# Altium schematic sheet PedalBox.SchDoc
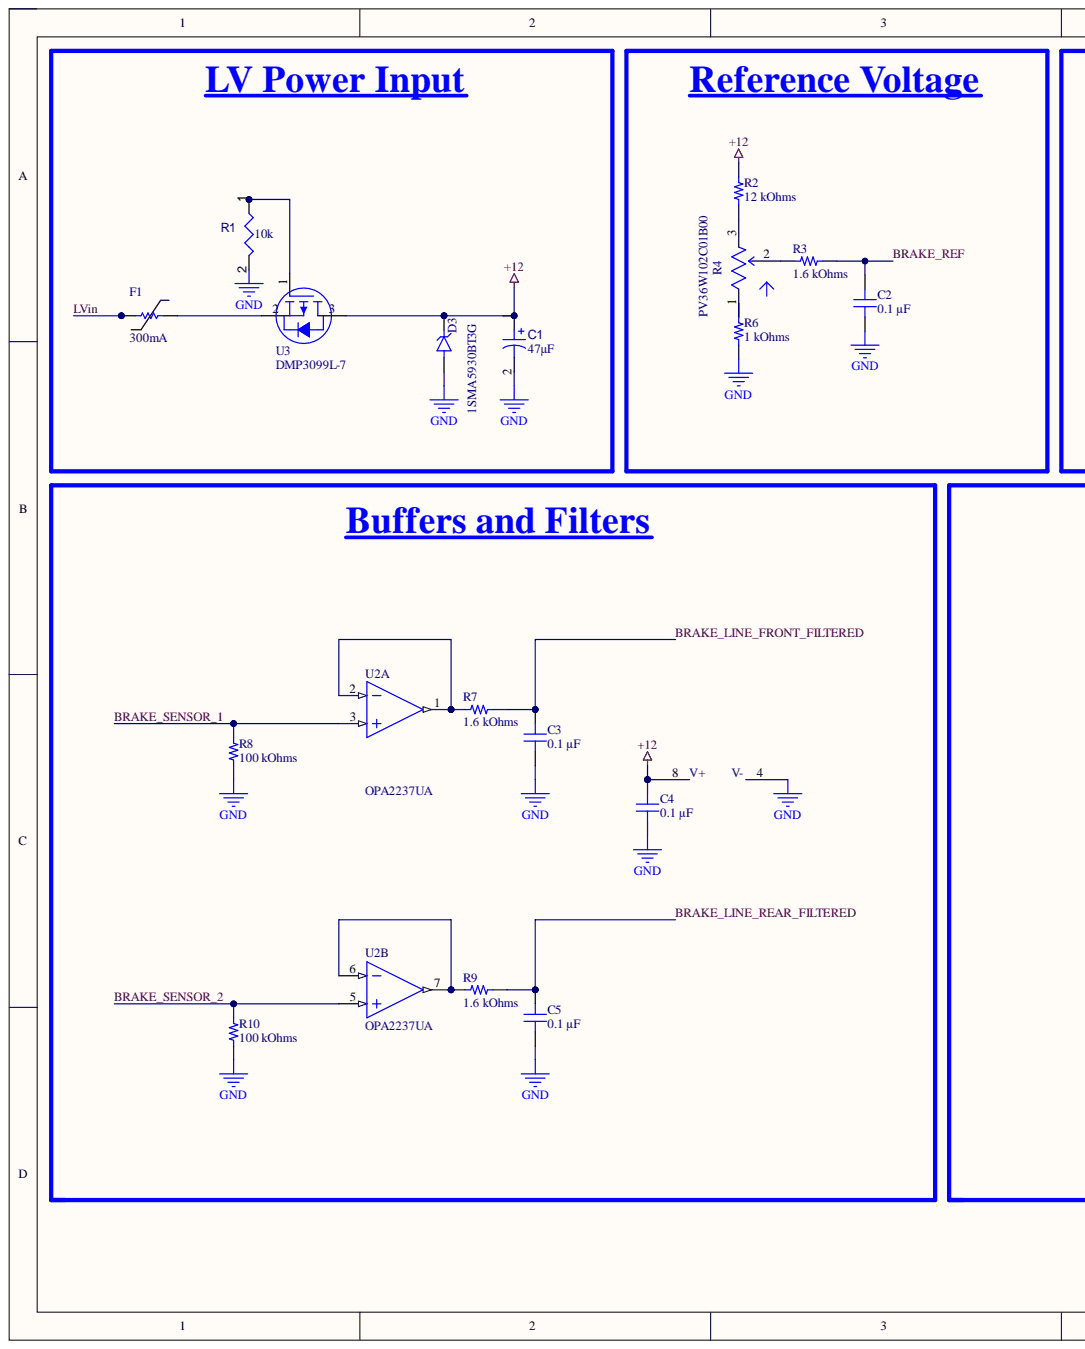
[diagram p0: PedalBox.SchDoc, left]
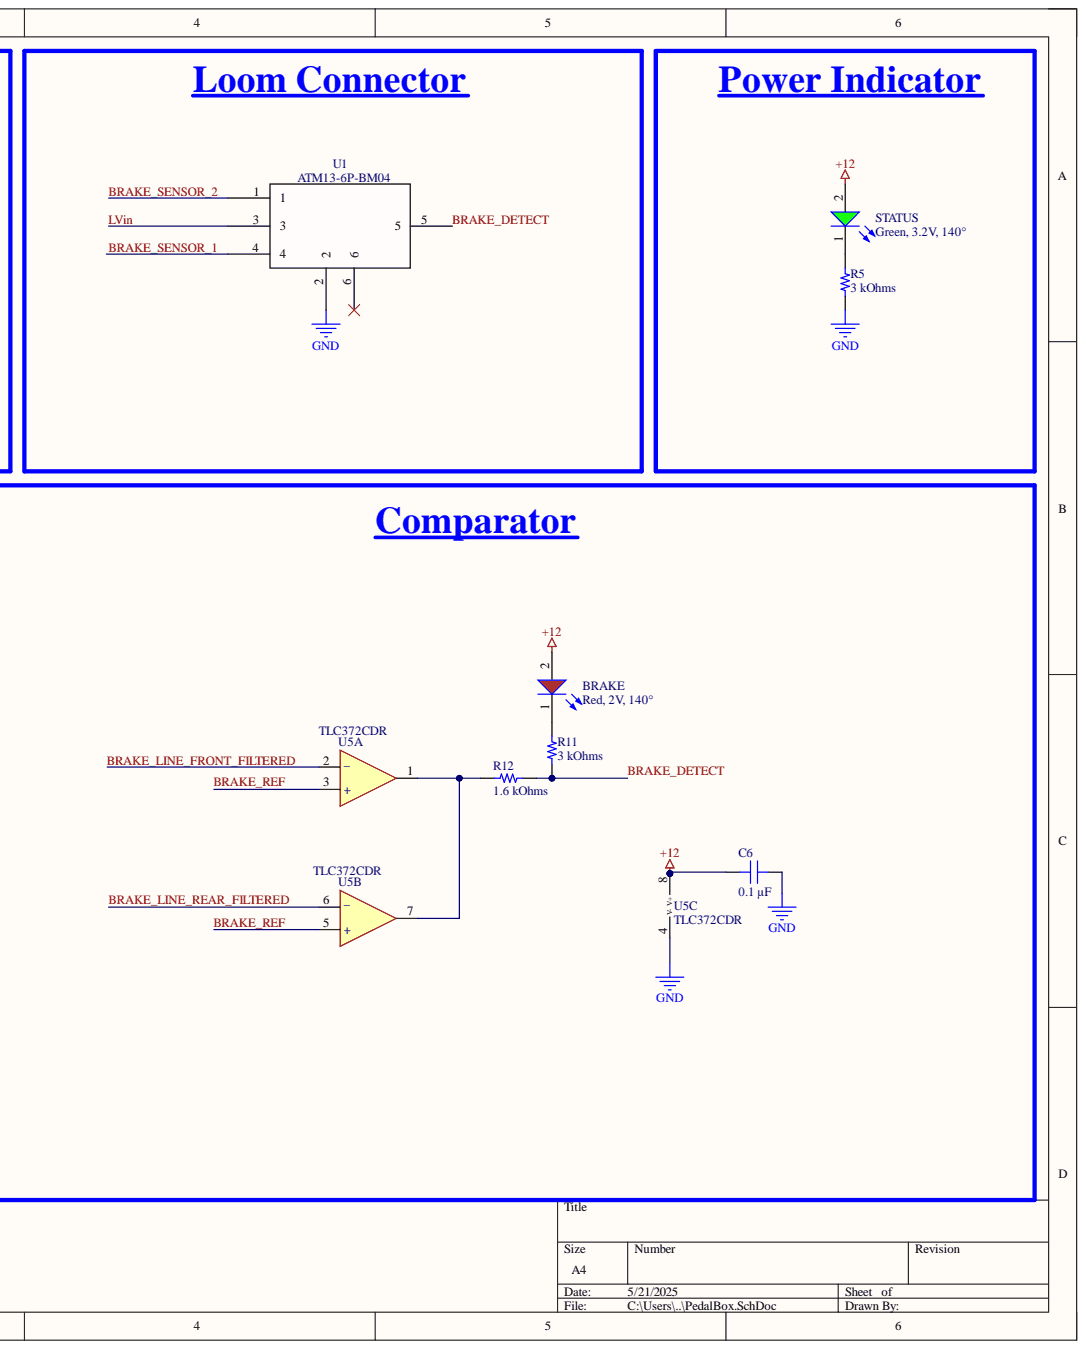
[diagram p1: PedalBox.SchDoc, right]

## Parts
BRAKE  Red, 2V, 140°  [WL-SMCW_0805_150080xx75000]
C1  value 47µF  [293D476X9025D2TE3-Footprint-1]  (hidden comment: 293D476X9025D2TE3)
C2  0.1 µF  [CAP 0603_1608]
C3  0.1 µF  [CAP 0603_1608]
C4  0.1 µF  [CAP 0603_1608]
C5  0.1 µF  [CAP 0603_1608]
C6  0.1 µF  [CAP 0603_1608]
D3  1SMA5930BT3G  [DIOM5226X220N]
F1  300mA  [RESC1608N]
R1  value 10k  [RESC1608X55X25ML10T15]  (hidden comment: Resistor 10k +/-1% 0603 100 mW)
R2  12 kOhms  [RES 0603_1608]
R3  1.6 kOhms  [RES 0603_1608]
R4  PV36W102C01B00  [FP-PV36W-MFG]
R5  3 kOhms  [RES 0603_1608]
R6  1 kOhms  [RES 0603_1608]
R7  1.6 kOhms  [RES 0603_1608]
R8  100 kOhms  [RES 0603_1608]
R9  1.6 kOhms  [RES 0603_1608]
R10  100 kOhms  [RES 0603_1608]
R11  3 kOhms  [RES 0603_1608]
R12  1.6 kOhms  [RES 0603_1608]
STATUS  Green, 3.2V, 140°  [WL-SMCW_0805_150080xx75000]
U1  ATM13-6P-BM04  [ATM13-6P-BM04_AMP]
U2  OPA2237UA  [D0008A_L]  (parts A,B)
U3  DMP3099L-7  [FP-SOT23-IPC_C]
U5  TLC372CDR  [TLC372CDR-Footprint-1]  (parts A,B,C)

## Nets
+12: BRAKE.2 C1.1 C4.2 C6.1 D3.C R2.2 STATUS.2 U2.8 U3.3 U5.8(V+)
GND: C1.2 C2.1 C3.1 C4.1 C5.1 C6.2 D3.A R1.2 R5.1 R6.1 R8.1 R10.1 U1.2 U2.4 U5.4(V-)
BRAKE_DETECT: R11.1 R12.2 U1.5
BRAKE_LINE_FRONT_FILTERED: C3.2 R7.2 U5.2
BRAKE_LINE_REAR_FILTERED: C5.2 R9.2 U5.6
BRAKE_REF: C2.2 R3.2 U5.3(+) U5.5(+)
BRAKE_SENSOR_1: R8.2 U1.4 U2.3
BRAKE_SENSOR_2: R10.2 U1.1 U2.5
LVin: F1.1 U1.3
NetBRAKE_1: BRAKE.1 R11.2
NetF1_2: F1.2 U3.2
NetR1_1: R1.1 U3.1
NetR2_1: R2.1 R4.3
NetR3_1: R3.1 R4.2
NetR4_1: R4.1 R6.2
NetR5_2: R5.2 STATUS.1
NetR7_1: R7.1 U2.1 U2.2
NetR9_1: R9.1 U2.6 U2.7
NetR12_1: R12.1 U5.1 U5.7

Not connected: U1 6

## Library data of the parts
BRAKE = 150080RS75000: WL-SMCW Mono-color Chip LED Waterclear, SMT, size 0805, Red, 2V, 140°; Manufacturer: Wurth Elektronik; Case/Size Code: 0805; Chip Technology: AlInGaP; Dominant Wavelength: 625nm; Luminous Intensity: 150mcd; Peak Wavelength: 630nm; Category: Signal LEDs; Component Kind: Standard; ComponentLink1Description: Website Link; ComponentLink1URL: https://www.we-online.com/redexpert/article/150080RS75000?ad; ComponentLink2Description: Datasheet Link; ComponentLink2URL: https://www.we-online.com/redexpert/spec/150080RS75000?ad; Emitting Color: Red; Forward Voltage: 2V; Height: 0.7mm; Length: 2mm; Library Name: Altium_WL-SMCW_rev22d.IntLib; Manufacturer Part Number: 150080RS75000; Match Code: WL-SMCW; Mount: Surface Mount; Operating Temperature Max: 85°C; Operating Temperature Min: -40°C; Packaging: Tape and Reel; Simulation: 0805_150080RS75000; Viewing Angle: 140°; Width: 1.25mm
C1 = CMP-001-00090-3: Tantalum Capacitor, Polarized, Tantalum (dry/solid), 25V, 10% +Tol, 10% -Tol, 47uF, Surface Mount, 2917; Ripple Current: 460mA; Capacitor Type: Tantalum; Case/Package: 2917; Component Kind: Standard; Max Operating Temperature: 125°C; Min Operating Temperature: -55°C; Mounting Technology: Surface Mount; Pins: 2; RoHS Compliant: Yes; Library Name: APAC - University of Canterbury - UC Motorsport (UCM)
C2-C6 = 100X14W104MV4T: CAP CER 0.1UF 10V X7R 0603; manufacturer: Johanson Dielectrics Inc.; Database Table Name: Capacitors - Ceramic - 0603; Tolerance: ±20%; Applications: Bypass, Decoupling; Capacitance: 0.1 µF; Component Kind: Standard; componentlink1description: Datasheet; componentlink1url: https://www.johansondielectrics.com/downloads/x2y-filter-and-decoupling-capacitors.pdf; componentlink2description: DigiKey Link; componentlink2url: https://www.digikey.com/en/products/detail/johanson-dielectrics-inc/100X14W104MV4T/1655914; Device Package: 0603 (1608 Metric); Features: Low ESL (X2Y); lastupdated: 9/02/2024 8:10:30 pm; Library Name: Celestial Altium Library - altium_library.DbLib; Lifecycle Status: Active; Minimum Order: 1; Mounting Type: Surface Mount, MLCC; Operating Temperature: -55°C ~ 125°C; Package / Case: 0603 (1608 Metric); packaging: TapeAndReel; Part Number: 100X14W104MV4T; Part Status: Active; partid: 2680; price: 0.08; series: X2Y®; Size / Dimension: 0.064" L x 0.035" W (1.63mm x 0.89mm); Supplier 1: DigiKey; Supplier Part Number 1: 709-1101-1-ND; Temperature Coefficient: X7R; Thickness (Max): 0.026" (0.66mm); value: 0.1 µF; Voltage - Rated: 10V
D3 = CMP-004-00032-1: Availability: Unavailable; Case/Package: None; Component Kind: Standard; Component Type: Standard; Design Item ID: 1SMA5930BT3G; MP: 1SMA5930BT3G; Pins: 2; Price: None; Source: 1SMA5930BT3G.SchLib; Library Name: APAC - University of Canterbury - UC Motorsport (UCM)
F1 = FS_Fuse_PTC_0.3A: FUSE PTC RESETTABLE 0603 SMD 0.1A; Manufacturer: Bourns Inc.; Manufacturer Part Number: MF-FSMF010X-2; Current - Hold (Ih) (Max): 100mA; Current - Max: 40A; R Min/Max: 0.900 ~ 6.000 Ohm; Component Kind: Standard; Current - Trip (It): 300mA; Library Name: ECE_ComponentsAD23_2.IntLib; Package / Case: 0603 (1608 Metric), Concave; Supplier 1: Digi-Key; Supplier Part Number 1: MF-FSMF010X-2CT-ND
R1 = CMP-009-00017-5: Chip Resistor, 10 KOhm, +/- 1%, 01 W, -55 to 155 degC, 0603 (1608 Metric); Power: 100mW; Voltage Rating: 50V; Case/Package: 0603; Component Kind: Standard; Max Operating Temperature: 155°C; Min Operating Temperature: -55°C; Mounting Technology: SM; Pins: 2; RoHS Compliant: True; Tolerance: 1%; Library Name: APAC - University of Canterbury - UC Motorsport (UCM)
R2 = RMCF0603JT12K0: RES 12K OHM 5% 1/10W 0603; Manufacturer: Stackpole Electronics Inc; Database Table Name: Resistors - Surface Mount - 0603; Power (Watts): 0.1W, 1/10W; Temperature Coefficient: ±200ppm/°C; Component Kind: Standard; ComponentLink1Description: Datasheet; ComponentLink1URL: https://www.seielect.com/catalog/sei-rmcf_rmcp.pdf; ComponentLink2Description: DigiKey Link; ComponentLink2URL: https://www.digikey.com/en/products/detail/stackpole-electronics-inc/RMCF0603JT12K0/1757966; Composition: Thick Film; Device Package: 0603 (1608 Metric); Features: Automotive AEC-Q200; Height - Seated (Max): 0.022" (0.55mm); LastUpdated: 9/02/2024 8:43:36 pm; Library Name: Celestial Altium Library - altium_library.DbLib; Lifecycle Status: Active; Minimum Order: 1; Number of Terminations: 2; Operating Temperature: -55°C ~ 155°C; Package / Case: 0603 (1608 Metric); Packaging: CutTape; Part Number: RMCF0603JT12K0; Part Status: Active; PartId: 5166; Price: 0.01; Ratings: AEC-Q200; Resistance: 12 kOhms; Series: RMCF; Size / Dimension: 0.061" L x 0.031" W (1.55mm x 0.80mm); Supplier 1: DigiKey; Supplier Device Package: 0603; Supplier Part Number 1: RMCF0603JT12K0CT-ND; Tolerance: ±5%; Value: 12 kOhms
R3 R7 R9 R12 = ERJ-PB3B1601V: RES SMD 1.6K OHM 0.1% 1/5W 0603; Manufacturer: Panasonic Electronic Components; Database Table Name: Resistors - Surface Mount - 0603; Power (Watts): 0.2W, 1/5W; Temperature Coefficient: ±50ppm/°C; Component Kind: Standard; ComponentLink1Description: Datasheet; ComponentLink1URL: https://industrial.panasonic.com/cdbs/www-data/pdf/RDM0000/AOA0000C328.pdf; ComponentLink2Description: DigiKey Link; ComponentLink2URL: https://www.digikey.com/en/products/detail/panasonic-electronic-components/ERJ-PB3B1601V/6212776; Composition: Thick Film; Device Package: 0603 (1608 Metric); Features: Automotive AEC-Q200, Pulse Withstanding; Height - Seated (Max): 0.022" (0.55mm); LastUpdated: 9/02/2024 8:43:37 pm; Library Name: Celestial Altium Library - altium_library.DbLib; Lifecycle Status: Active; Minimum Order: 1; Number of Terminations: 2; Operating Temperature: -55°C ~ 155°C; Package / Case: 0603 (1608 Metric); Packaging: TapeAndReel; Part Number: ERJ-PB3B1601V; Part Status: Active; PartId: 4914; Price: 0.02; Ratings: AEC-Q200; Resistance: 1.6 kOhms; Series: ERJ-PB3; Size / Dimension: 0.063" L x 0.031" W (1.60mm x 0.80mm); Supplier 1: DigiKey; Supplier Device Package: 0603; Supplier Part Number 1: P19993CT-ND; Tolerance: ±0.1%; Value: 1.6 kOhms
R4 = CMP-07234-000009-1: TRIMMER 1K OHM 0.5W PC PIN TOP; Manufacturer: Bourns; Resistance: 1kΩ; Standoff Height: 0.38mm; Temperature Coefficient: 100ppm/°C; Tolerance: 10%; Value: 1kΩ; Automotive: No; Category: Res; Component Kind: Standard; ComponentLink2Description: Datasheet; ComponentLink2URL: https://www.bourns.com/docs/Product-Datasheets/pv36.pdf; Composition: Cermet; Device Class L1: Passive Components; Device Class L2: Resistors; Device Class L3: Variable Resistors and Potentiometers; Digikey Description: TRIMMER 1K OHM 0.5W PC PIN TOP; Height: 12.05mm; Lead Free: Yes; Library Name: Altium Content Vault; Manufacturer Part Number: PV36W102C01B00; Mouser Description: Trimmer Resistors - Through Hole 1.0Kohms 10mm Square 25turn; Octopart: https://octopart.com/pv36w102c01b00-bourns-71612321; Package: PV36W; Power Rating: 0.5W; RoHS: TRUE; Series: PV36; Temperature: -55°C to +125°C; Temperature Range High: +125°C; Temperature Range Low: -55°C
R5 R11 = TNPW06033K00BYEN: RES 3K OHM 0.1% 1/10W 0603; Manufacturer: Vishay Dale; Database Table Name: Resistors - Surface Mount - 0603; Power (Watts): 0.1W, 1/10W; Temperature Coefficient: ±10ppm/°C; Component Kind: Standard; ComponentLink1Description: Datasheet; ComponentLink1URL: http://www.vishay.com/docs/28758/tnpw_e3.pdf; ComponentLink2Description: DigiKey Link; ComponentLink2URL: https://www.digikey.com/en/products/detail/vishay-dale/TNPW06033K00BYEN/8636652; Composition: Thin Film; Device Package: 0603 (1608 Metric); Features: Anti-Sulfur, Automotive AEC-Q200, Moisture Resistant; Height - Seated (Max): 0.022" (0.55mm); LastUpdated: 9/02/2024 8:43:35 pm; Library Name: Celestial Altium Library - altium_library.DbLib; Lifecycle Status: Active; Minimum Order: 1; Number of Terminations: 2; Operating Temperature: -55°C ~ 155°C; Package / Case: 0603 (1608 Metric); Packaging: TapeAndReel; Part Number: TNPW06033K00BYEN; Part Status: Active; PartId: 5287; Price: 0.25; Ratings: AEC-Q200; Resistance: 3 kOhms; Series: TNPW e3; Size / Dimension: 0.061" L x 0.033" W (1.55mm x 0.85mm); Supplier 1: DigiKey; Supplier Device Package: 0603; Supplier Part Number 1: 541-9825-1-ND; Tolerance: ±0.1%; Value: 3 kOhms
R6 = CPF0603B1K0E: RES SMD 1K OHM 0.1% 1/16W 0603; Manufacturer: TE Connectivity Passive Product; Database Table Name: Resistors - Surface Mount - 0603; Power (Watts): 0.063W, 1/16W; Temperature Coefficient: ±25ppm/°C; Component Kind: Standard; ComponentLink1Description: Datasheet; ComponentLink1URL: https://www.te.com/commerce/DocumentDelivery/DDEController?Action=srchrtrv&DocNm=1773200&DocType=DS&DocLang=English; ComponentLink2Description: DigiKey Link; ComponentLink2URL: https://www.digikey.com/en/products/detail/te-connectivity-passive-product/CPF0603B1K0E/2390473; Composition: Thin Film; Device Package: 0603 (1608 Metric); Height - Seated (Max): 0.022" (0.55mm); LastUpdated: 9/02/2024 8:43:37 pm; Library Name: Celestial Altium Library - altium_library.DbLib; Lifecycle Status: Active; Minimum Order: 1; Number of Terminations: 2; Operating Temperature: -55°C ~ 155°C; Package / Case: 0603 (1608 Metric); Packaging: TapeAndReel; Part Number: CPF0603B1K0E; Part Status: Active; PartId: 4877; Price: 0.09; Resistance: 1 kOhms; Series: CPF, Neohm; Size / Dimension: 0.061" L x 0.031" W (1.55mm x 0.80mm); Supplier 1: DigiKey; Supplier Device Package: 0603; Supplier Part Number 1: A119887CT-ND; Tolerance: ±0.1%; Value: 1 kOhms
R8 R10 = CPF0603B100KE: RES SMD 100K OHM 0.1% 1/16W 0603; Manufacturer: TE Connectivity Passive Product; Database Table Name: Resistors - Surface Mount - 0603; Power (Watts): 0.063W, 1/16W; Temperature Coefficient: ±25ppm/°C; Component Kind: Standard; ComponentLink1Description: Datasheet; ComponentLink1URL: https://www.te.com/commerce/DocumentDelivery/DDEController?Action=srchrtrv&DocNm=1773200&DocType=DS&DocLang=English; ComponentLink2Description: DigiKey Link; ComponentLink2URL: https://www.digikey.com/en/products/detail/te-connectivity-passive-product/CPF0603B100KE/2381864; Composition: Thin Film; Device Package: 0603 (1608 Metric); Height - Seated (Max): 0.022" (0.55mm); LastUpdated: 9/02/2024 8:43:37 pm; Library Name: Celestial Altium Library - altium_library.DbLib; Lifecycle Status: Active; Minimum Order: 1; Number of Terminations: 2; Operating Temperature: -55°C ~ 155°C; Package / Case: 0603 (1608 Metric); Packaging: TapeAndReel; Part Number: CPF0603B100KE; Part Status: Active; PartId: 4883; Price: 0.09; Resistance: 100 kOhms; Series: CPF, Neohm; Size / Dimension: 0.061" L x 0.031" W (1.55mm x 0.80mm); Supplier 1: DigiKey; Supplier Device Package: 0603; Supplier Part Number 1: A119912CT-ND; Tolerance: ±0.1%; Value: 100 kOhms
STATUS = 150080GS75000: WL-SMCW Mono-color Chip LED Waterclear, SMT, size 0805, Green, 3.2V, 140°; Manufacturer: Wurth Elektronik; Case/Size Code: 0805; Chip Technology: InGaN; Dominant Wavelength: 525nm; Luminous Intensity: 450mcd; Peak Wavelength: 515nm; Category: Signal LEDs; Component Kind: Standard; ComponentLink1Description: Website Link; ComponentLink1URL: https://www.we-online.com/redexpert/article/150080GS75000?ad; ComponentLink2Description: Datasheet Link; ComponentLink2URL: https://www.we-online.com/redexpert/spec/150080GS75000?ad; Emitting Color: Green; Forward Voltage: 3.2V; Height: 0.7mm; Length: 2mm; Library Name: Altium_WL-SMCW_rev22d.IntLib; Manufacturer Part Number: 150080GS75000; Match Code: WL-SMCW; Mount: Surface Mount; Operating Temperature Max: 85°C; Operating Temperature Min: -40°C; Packaging: Tape and Reel; Simulation: 0805_150080GS75000; Viewing Angle: 140°; Width: 1.25mm
U1 = ATM13-6P-BM04: No Description Available; Mfr_Name: Amphenol ICC; Component Kind: Standard; Library Name: ATM13-6P-BM04.IntLib; RefDes: RefDes; Type: Type
U2 = CMP-00000-4: Operational Amplifier, 2 Func, 950uV Offset-Max, BIPolar, PDSO8; Architecture: VOLTAGE-FEEDBACK; Average Bias Current-Max (IIB): 40nA; Channels: 2; Common-mode Reject Ratio-Nom: 90dB; Frequency Compensation: YES; Max Supply Current: 950uA; Neg Supply Voltage Limit-Max: -18V; Neg Supply Voltage-Nom (Vsup): -15V; Nominal Supply Voltage: 15V; Package Body Material: Plastic; Package Equivalence Code: SOP8,.25; Power Supplies: +-1.35/+-18/2.7/36V; Qualification Status: Not Qualified; Slew Rate: 0.5V/µs; Supply Voltage Limit-Max: 18V; Unity Gain BW-Nom: 1.5MHz; Voltage Gain-Min: 10000; Amplifier Type: OPERATIONAL AMPLIFIER; Bias Current-Max (IIB) @25C: 40nA; Case/Package: SOP; Component Kind: Standard; Height - Seated (Max): 1.75mm; Input Offset Voltage-Max: 950uV; JESD-30 Code: R-PDSO-G8; JESD-609 Code: e4; Length: 4.9mm; Low-Offset: Yes; Max Operating Temperature: 125°C; Micropower: Yes; Min Operating Temperature: -55°C; Moisture Sensitivity Level: 3; Package Shape: Rectangular; Package Style: SMALL OUTLINEMeter; Packaging: Rail/Tube; Peak Reflow Temperature (Cel): 260°C; Pins: 8; Reach SVHC Compliant: Yes; RoHS Compliant: Yes; Surface Mount: Yes; Technology: BIPOLAR; Temperature Grade: MILITARY; Terminal Finish: Nickel; Terminal Form: Gull Wing; Terminal Pitch: 1.27mm; Terminal Position: DUAL; Width: 3.9mm; Library Name: APAC - University of Canterbury - UC Motorsport (UCM)
U3 = CMP-013-00040-1: Power Field-Effect Transistor, 3.8A I(D), 30V, 0.065ohm, 1-Element, P-Channel, Silicon, Metal-oxide Semiconductor FET; Component Kind: Standard; Max Operating Temperature: 150°C; Pins: 3; RoHS Compliant: Yes; Library Name: APAC - University of Canterbury - UC Motorsport (UCM)
U5 = CMP-016-00010-2: Comparator, 2 Func, 6500uV Offset-Max, 650ns Response Time, CMOS, PDSO8; Nominal Supply Voltage: 5V; Case/Package: SOP; Component Kind: Standard; Max Operating Temperature: 70°C; Min Operating Temperature: 0°C; Pins: 8; RoHS Compliant: Yes; Library Name: APAC - University of Canterbury - UC Motorsport (UCM)
